# Altium schematic sheet Stage2B_5volt_buck.SchDoc
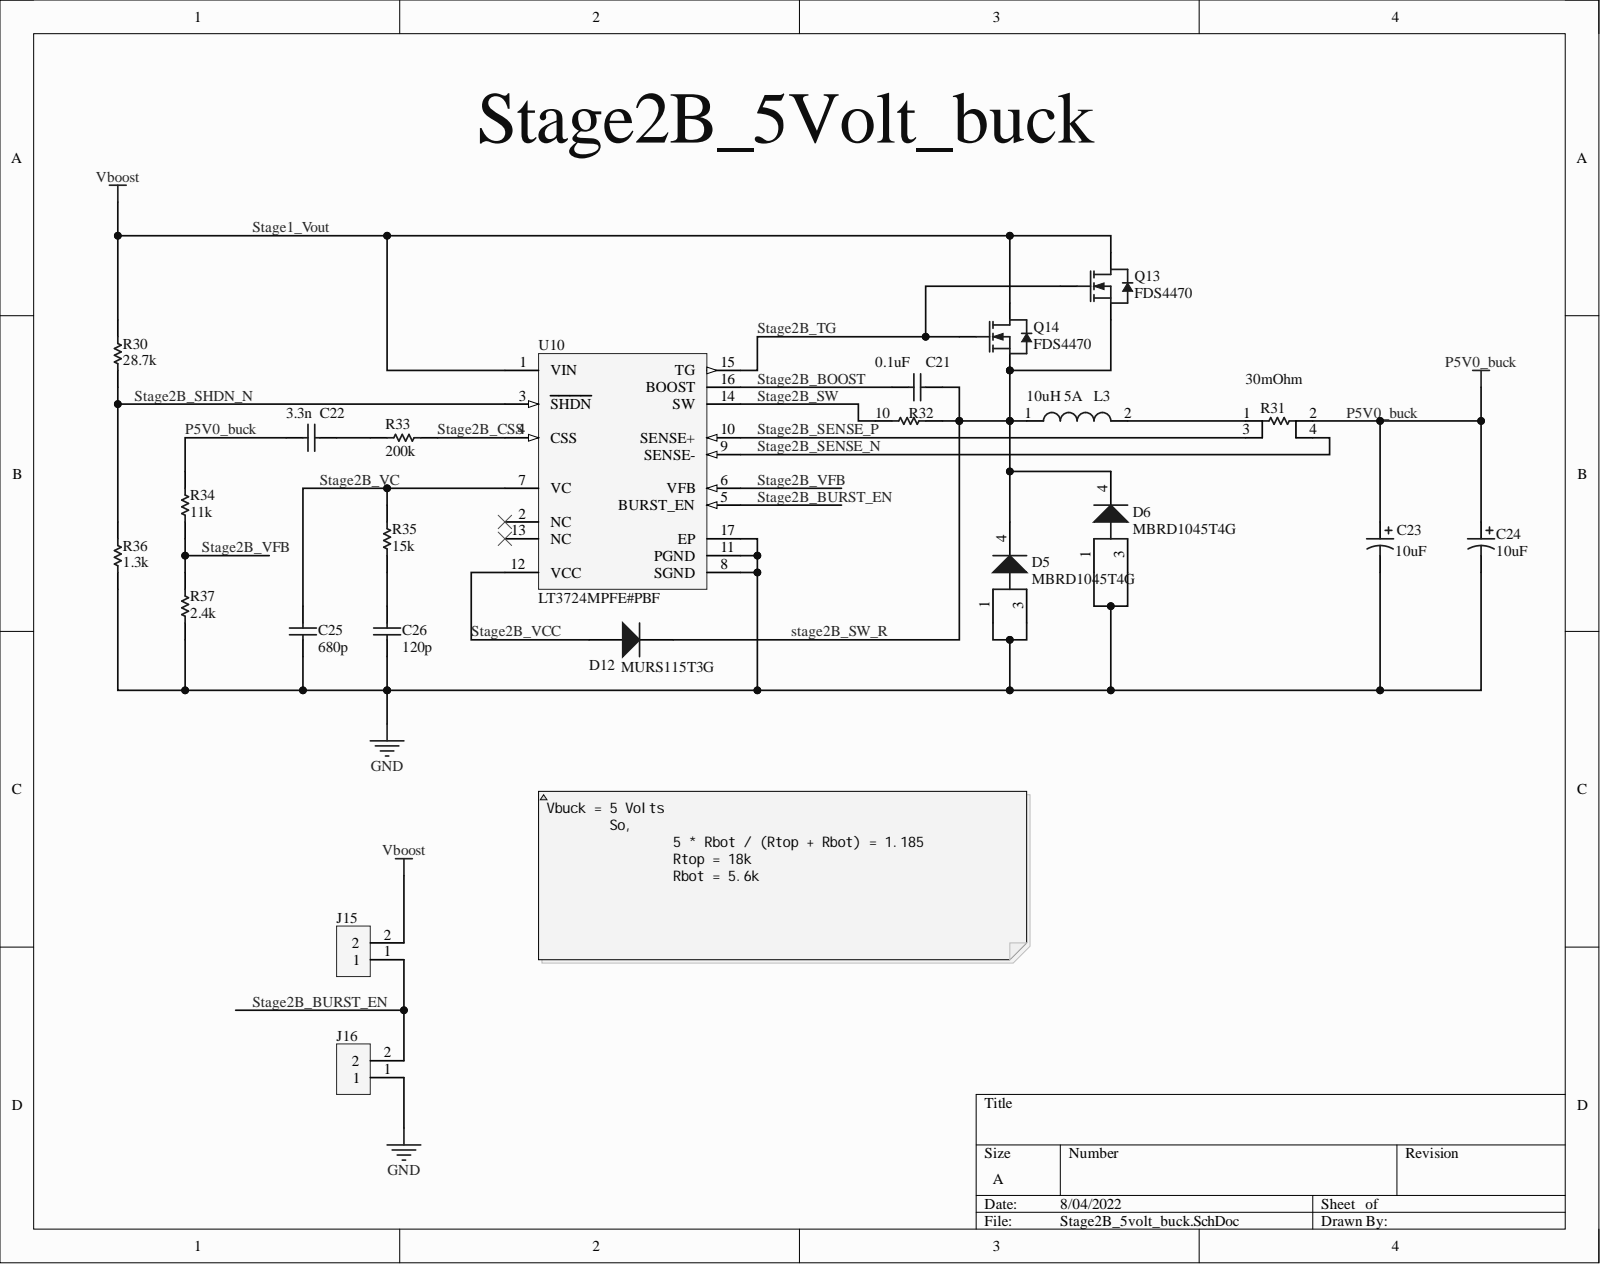
[diagram p0: Stage2B_5volt_buck.SchDoc]

## Parts
C21  0.1uF  [PCB-0002-00735-1]
C22  3.3n  [PCB-iub6dlq54ohgyg9g95v4-1]
C23  10uF  [PCB-45uljczbzgwzo9m7ql45-1]
C24  10uF  [PCB-45uljczbzgwzo9m7ql45-1]
C25  680p  [CAPC2013X145X48ML20T23]
C26  120p  [CAPC2013X145X48ML20T23]
D5  MBRD1045T4G  [ONSC-DPAK-3-369C-01_V]
D6  MBRD1045T4G  [ONSC-DPAK-3-369C-01_V]
D12  MURS115T3G  [ONSC-SMB-2-403A-03_V]
J15  (no value)  [PCB-omgsbkhp90n7lpri88og-1]
J16  (no value)  [PCB-omgsbkhp90n7lpri88og-1]
L3  10uH 5A  [WE-PD-L]
Q13  FDS4470  [PCB-vam1no1ldi8601k0q85a-1]
Q14  FDS4470  [PCB-vam1no1ldi8601k0q85a-1]
R30  28.7k  [RESC2013X60X35LL10T20]
R31  30mOhm  [PCB-e4z1ou8eehx9zfei5nig-1]
R32  10  [RESC2013X70X40LL20T20]
R33  200k  [PCB-ec8bjsgds03v1bsrpylx-1]
R34  11k  [RESC2013X60X35LL10T20]
R35  15k  [RESC2013X60X35LL10T20]
R36  1.3k  [RESC2013X60X30NL20T20]
R37  2.4k  [RESC2013X60X35LL10T20]
U10  LT3724MPFE#PBF  [LT-FE-16-EPV-BC_M]

## Nets
GND: C23.2 C24.2 C25.1 C26.1 D5.1 D5.3 D6.1 D6.3 J16.1 R36.1 R37.1 U10.8(SGND) U10.11(PGND) U10.17(EP)
P5V0_buck: C22.1 C23.1 C24.1 R31.2 R34.2
Stage1_Vout: C21.2 D5.4 D6.4 D12.2 J15.2 L3.1 Q13.2 Q13.3 Q14.2 Q14.3 R30.2 R32.2 U10.1(VIN)  [also labelled stage2B_SW_R]
Stage2B_BOOST: C21.1 U10.16(BOOST)
Stage2B_BURST_EN: J15.1 J16.2 U10.5(BURST_EN)
Stage2B_CSS: R33.2 U10.4(CSS)
Stage2B_SENSE_N: R31.4 U10.9(SENSE-)
Stage2B_SENSE_P: R31.3 U10.10(SENSE+)
Stage2B_SHDN_N: R30.1 R36.2 U10.3(SHDN)
Stage2B_SW: R32.1 U10.14(SW)
Stage2B_TG: Q13.1 Q14.1 U10.15(TG)
Stage2B_VC: C25.2 R35.2 U10.7(VC)
Stage2B_VCC: D12.1 U10.12(VCC)
Stage2B_VFB: R34.1 R37.2 U10.6(VFB)
NetC22_2: C22.2 R33.1
NetC26_2: C26.2 R35.1
NetL3_2: L3.2 R31.1

Not connected: U10 2(NC) 13(NC)

## Library data of the parts
C21 = CMP-126393-3: CAP CER 0.1UF 50V 10% X7R 0805; Manufacturer Part Number: 08055C104KAZ2A; Capacitance: 1e-07 F; Case/Package (SI): 2012; Dielectric Material: Ceramic Multilayer; Lead-Free Status: Lead Free; Voltage Rating (DC): 50.0 V; Capacitance Tolerance: ±10%; Case/Package: 0805; Component Kind: Standard; Dielectric Characteristic: X7R; Library Name: My Personal Space; Mounting Style: Surface Mount; Number of Pins: 2; Octopart Url: https://octopart.com/08055c104kaz2a-avx+interconnect+%2F+elco-195624; OctopartID: aa35633d737b75f2; REACH SVHC Compliance: No SVHC; RoHS: Compliant; Size-Height: 0.00094 m; Size-Length: 0.00201 m; Size-Width: 0.00125 m
C22 = CMP-44648f345bea9ba3-1: Multilayer Ceramic Capacitor, CC Series, 3300 pF, - 10%, X7R, 50 V, 0805 [2012 Metric]; Manufacturer Part Number: CC0805KRX7R9BB332; Capacitance: 3.30 nF; Case/Package (SI): 2012; Insulation Resistance: 100 GΩ; Lead-Free Status: Lead Free; Voltage Rating (DC): 50.0 V; Capacitance Tolerance: ±10%; Case/Package: 0805; Component Kind: Standard; Dielectric Characteristic: X7R; Dielectric Material: Ceramic; Halogen Free Status: Halogen Free; Library Name: My Personal Space; Mounting Style: Surface Mount; Number of Pins: 2; Octopart URL: http://octopart.com/cc0805krx7r9bb332-yageo-39467752; OctopartID: 44648f345bea9ba3; Packaging: Cut Tape (CT); REACH SVHC Compliance: No SVHC; RoHS: Compliant; Size-Height: 940 µm; Size-Length: 2.01 mm; Size-Width: 1.25 mm
C23 C24 = CMP-7610bd40367da9d7-6: Capacitor; Aluminum Electrolytic; Fc Radial Elec Cap 10uF 50V; Manufacturer Part Number: EEU-FC1H100L; Capacitance: 10.0 µF; Capacitance Tolerance: ±20%; Lead-Free Status: Lead Free; Voltage Rating (DC): 50.0 V; Component Kind: Standard; Dielectric Material: Aluminum Electrolytic; Library Name: My Personal Space; Lifecycle Status: Not Listed by Manufacturer; Mounting Style: Through Hole; Number of Pins: 2; Octopart URL: https://octopart.com/eeu-fc1h100l-panasonic-39478539; OctopartID: 7610bd40367da9d7; Operating Temperature: 105 °C (max); Packaging: Bulk; REACH SVHC Compliance: No SVHC; RoHS: Compliant; Size-Diameter: 5.00 mm; Size-Height: 11.0 mm; Size-Length: 11.0 mm
C25 C26 = CMP-3554732-2: Multilayer Ceramic Capacitors MLCC - SMD/SMT 0805 120pF 250V C0G 10% HI FREQ; Manufacturer Part Number: VJ0805D121KXPAJ; Capacitance: 1.2e-10 F; Dielectric Characteristic: C0G/NP0; Lead-Free Status: Lead Free; Voltage Rating (DC): 250.0 V; Capacitance Tolerance: ±10%; Component Kind: Standard; Library Name: My Personal Space; Octopart Url: https://octopart.com/vj0805d121kxpaj-vishay-45210306; OctopartID: d2bb19002d1f0d7c; Packaging: Cut Tape (CT); RoHS: Compliant
D5 D6 = CMP-10976408-2: SWITCHMODE(TM) Schottky Power Rectifier, 3-Pin DPAK, Pb-Free, Tape and Reel; Current Rating: 10.0 A; Lead-Free Status: Lead Free; Polarity: Standard; Voltage Rating (DC): 45.0 V; Case/Package: DPAK; Component Kind: Standard; Halogen Free Status: Halogen Free; Library Name: My Personal Space; Lifecycle Status: Active; Manufacturer Part Number: MBRD1045T4G; Mounting Style: Surface Mount; Number of Pins: 3; Octopart Url: https://octopart.com/mbrd1045t4g-on+semiconductor-1229353; OctopartID: f02c393417632feb; Packaging: Tape; REACH SVHC Compliance: No SVHC; RoHS: Compliant
D12 = CMP-19360973-4: Surface Mount Ultrafast Power Rectifier, 2-Pin SMB, Pb-Free, Tape and Reel; Current Rating: 1.0 A; Lead-Free Status: Lead Free; Polarity: Standard; Voltage Rating (DC): 150.0 V; Case/Package: SMB; Component Kind: Standard; Halogen Free Status: Halogen Free; Library Name: My Personal Space; Lifecycle Status: Active; Manufacturer Part Number: MURS115T3G; Mounting Style: Surface Mount; Number of Pins: 2; Octopart Url: https://octopart.com/murs115t3g-on+semiconductor-332425; OctopartID: eaf923f6dd16353e; Packaging: Tape; REACH SVHC Compliance: No SVHC; RoHS: Compliant
J15 J16 = CMP-748fbcb73d6bab48-30: Pitch 2.54 mm, Single Row, 2pins; Component Kind: Standard; Library Name: My Personal Space
L3 = CMP-912551-2: SMD-Shielded Power Inductor WE-PD, L = 10.0 µH; Manufacturer Part Number: 74477110; Inductance: 1e-05 H; Resistance: 0.018 Ω; Resonant Frequency: 21500000.0 Hz; Component Kind: Standard; Library Name: My Personal Space; Number of Pins: 2; Octopart Url: https://octopart.com/74477110-w%C3%BCrth+elektronik-8917130; OctopartID: 4fd586577a399012; Packaging: Cut Tape (CT); REACH SVHC Compliance: No SVHC; RoHS: Compliant; Self Resonant Frequency: 21500000.0 Hz
Q13 Q14 = CMP-cab003bfccd71577-2: FDS4470 Series 40 V 9 mOhm Surface Mount N-Channel PowerTrench Mosfet - SOIC-8; Breakdown Voltage (Drain to Source): -100.0 V; Continuous Drain Current (Ids): 12.5 A; Drain to Source Resistance (on) (Rds): 0.009 Ω; Drain to Source Voltage (Vds): 40.0 V; Gate Charge: 4.5e-08 C; Input Capacitance: 2.659e-09 F; Lead-Free Status: Lead Free; Power Dissipation: 2.5 W; Rise Time: 1.2e-08 s; Case/Package: SOIC; Component Kind: Standard; Current Rating: 12.5 A; Library Name: My Personal Space; Lifecycle Status: Active; Manufacturer Part Number: FDS4470; Mounting Style: Surface Mount; Number of Pins: 8; Octopart URL: http://octopart.com/fds4470-fairchild+semiconductor-336641; OctopartID: cab003bfccd71577; Packaging: Tape; Polarity: N-Channel; REACH SVHC Compliance: No SVHC; RoHS: Compliant; Voltage Rating (DC): 40.0 V
R30 = CMP-1854552-2: RES SMD 287K OHM 1% 1/8W 0805; Manufacturer Part Number: RC0805FR-07287KL; Case/Package (SI): 2012; Lead-Free Status: Lead Free; Power Rating: 0.125 W; Resistance: 287000.0 Ω; Voltage Rating (DC): 150.0 V; Case/Package: 0805; Component Kind: Standard; Library Name: My Personal Space; Mounting Style: Surface Mount; Octopart Url: https://octopart.com/rc0805fr-07287kl-yageo-1169350; OctopartID: 0d3bc420ff9bd2fc; Resistance Tolerance: ±1%; RoHS: Compliant; Size-Height: 0.0005 m; Size-Length: 0.002 m; Size-Width: 0.00125 m
R31 = CMP-41418819abb09e86-1: Res Metal Alloy 1206 0.03 Ohm 0.5% 0.5W(1/2W) ±50ppm/C Epoxy Resin Pad SMD T/R; Manufacturer Part Number: LVK12R030DER; Case/Package (SI): 3216; Power Rating: 500 mW; Resistance: 30.0 mΩ; Temperature Coefficient: -50.0 ppm/°C to 50.0 ppm/°C; Case/Package: 3216, 1206; Component Kind: Standard; Library Name: My Personal Space; Mounting Style: Surface Mount; Number of Pins: 2; Octopart URL: https://octopart.com/lvk12r030der-ohmite-5427095; OctopartID: 41418819abb09e86; Operating Temperature: -40.0 °C to 125 °C; Packaging: Tape & Reel (TR), Cut Tape (CT); Resistance Tolerance: ±0.5%; RoHS: Compliant
R32 = CMP-3653897-2: Thick Film Resistors - SMD 0805 10ohms 0.25W 5% Tol; Manufacturer Part Number: ERJ-P06J100V; Case/Package (SI): 2012; Lead-Free Status: Lead Free; Power Rating: 0.5 W; Resistance: 10.0 Ω; Voltage Rating (DC): 400.0 V; Component Kind: Standard; Library Name: My Personal Space; Lifecycle Status: Not Listed by Manufacturer; Mounting Style: Surface Mount; Octopart Url: https://octopart.com/erj-p06j100v-panasonic-39858980; OctopartID: e2332959f4713df4; Resistance Tolerance: ±5%; RoHS: Compliant; Size-Height: 0.0006 m; Size-Length: 0.002 m; Size-Width: 0.00125 m
R33 = CMP-009dc8cdd678df5a-1: Res Thin Film 0805 200K Ohm 0.1% 1/10W ±10ppm/°C Molded SMD SMD Paper T/R; Manufacturer Part Number: RN73C2A200KBTDF; Case/Package (SI): 2012; ELV Compliant: Compliant; Power Rating: 100 mW; Resistance: 200 kΩ; Temperature Coefficient: -10.0 ppm/°C to 10.0 ppm/°C; Voltage Rating (DC): 100 V; Case/Package: 2012, 0805; Component Kind: Standard; Library Name: My Personal Space; Lifecycle Status: Active; Mounting Style: Surface Mount; Octopart URL: https://octopart.com/rn73c2a200kbtdf-te+connectivity-22737795; OctopartID: 009dc8cdd678df5a; Packaging: Tape & Reel (TR); Resistance Tolerance: ±0.1%; RoHS: Compliant
R34 R35 R37 = CMP-1875647-2: RES SMD 38.3K OHM 1% 1/8W 0805; Manufacturer Part Number: RC0805FR-0738K3L; Case/Package (SI): 2012; Lead-Free Status: Lead Free; Power Rating: 0.125 W; Resistance: 38300.0 Ω; Voltage Rating (DC): 150.0 V; Case/Package: 0805; Component Kind: Standard; Library Name: My Personal Space; Mounting Style: Surface Mount; Octopart Url: https://octopart.com/rc0805fr-0738k3l-yageo-1177806; OctopartID: 2daae4b1f002f26d; Resistance Tolerance: ±1%; RoHS: Compliant; Size-Height: 0.0005 m; Size-Length: 0.002 m; Size-Width: 0.00125 m
R36 = CMP-3670361-2: Thick Film Resistors - SMD 0.25W 1.3Kohm 1% 200ppm    Learn More; Manufacturer Part Number: SG73P2ATTD1301F; Case/Package (SI): 2012; Resistance: 1300.0 Ω; Temperature Coefficient: 200.0 ppm/°C; Voltage Rating (DC): 150.0 V; Component Kind: Standard; Library Name: My Personal Space; Mounting Style: Surface Mount; Number of Pins: 2; Octopart Url: https://octopart.com/sg73p2attd1301f-koa+speer-7748804; OctopartID: f80e3172e1ac27c9; Power Rating: 0.25 W; Resistance Tolerance: ±1%; RoHS: Compliant
U10 = CMP-1478355-2: High Voltage, Current Mode Switching Regulator Controller, 16-pin SOP (FE-16-EPV-BC), -55 to 125 degC, Pb-Free; Lead-Free Status: Contains Lead; Component Kind: Standard; Library Name: My Personal Space; Manufacturer Part Number: LT3724MPFE#PBF; Octopart Url: https://octopart.com/lt3724mpfe%23pbf-linear+technology-12454061; OctopartID: 961f5fabbbd449d8; RoHS: Compliant
